# Altium schematic sheet main.SchDoc(main)
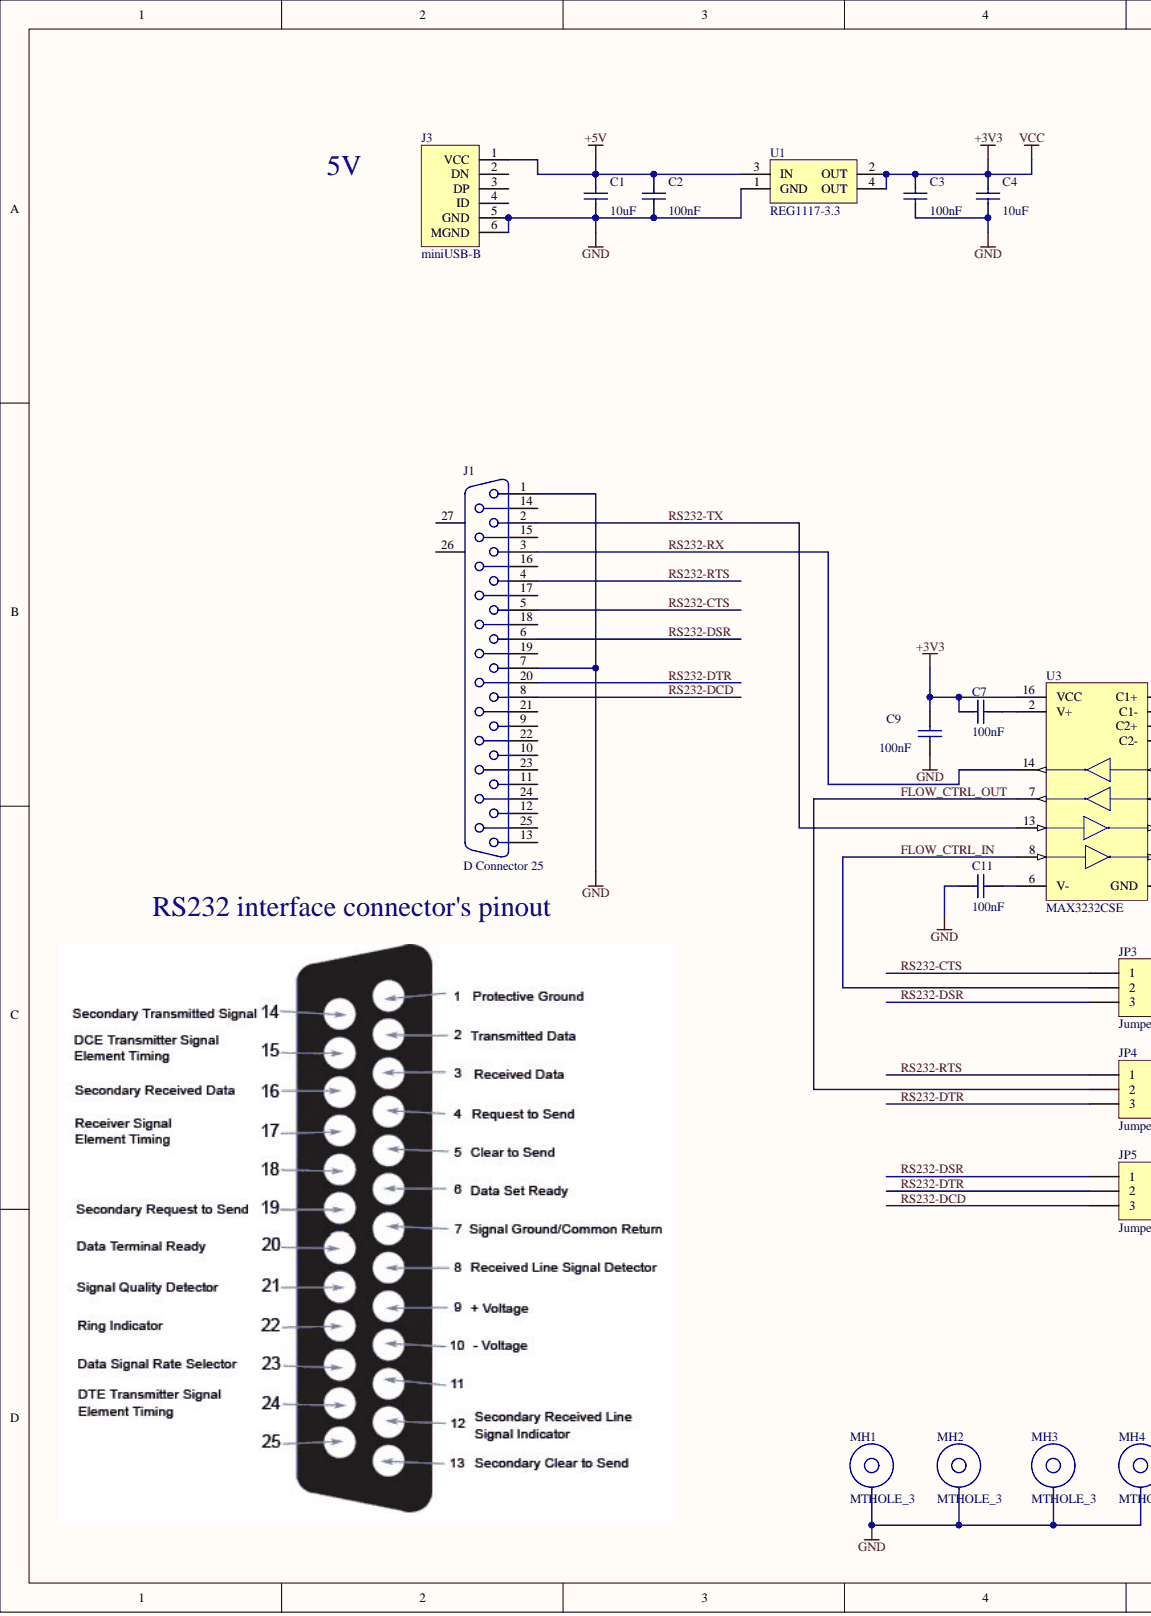
[diagram p0: main.SchDoc, left]
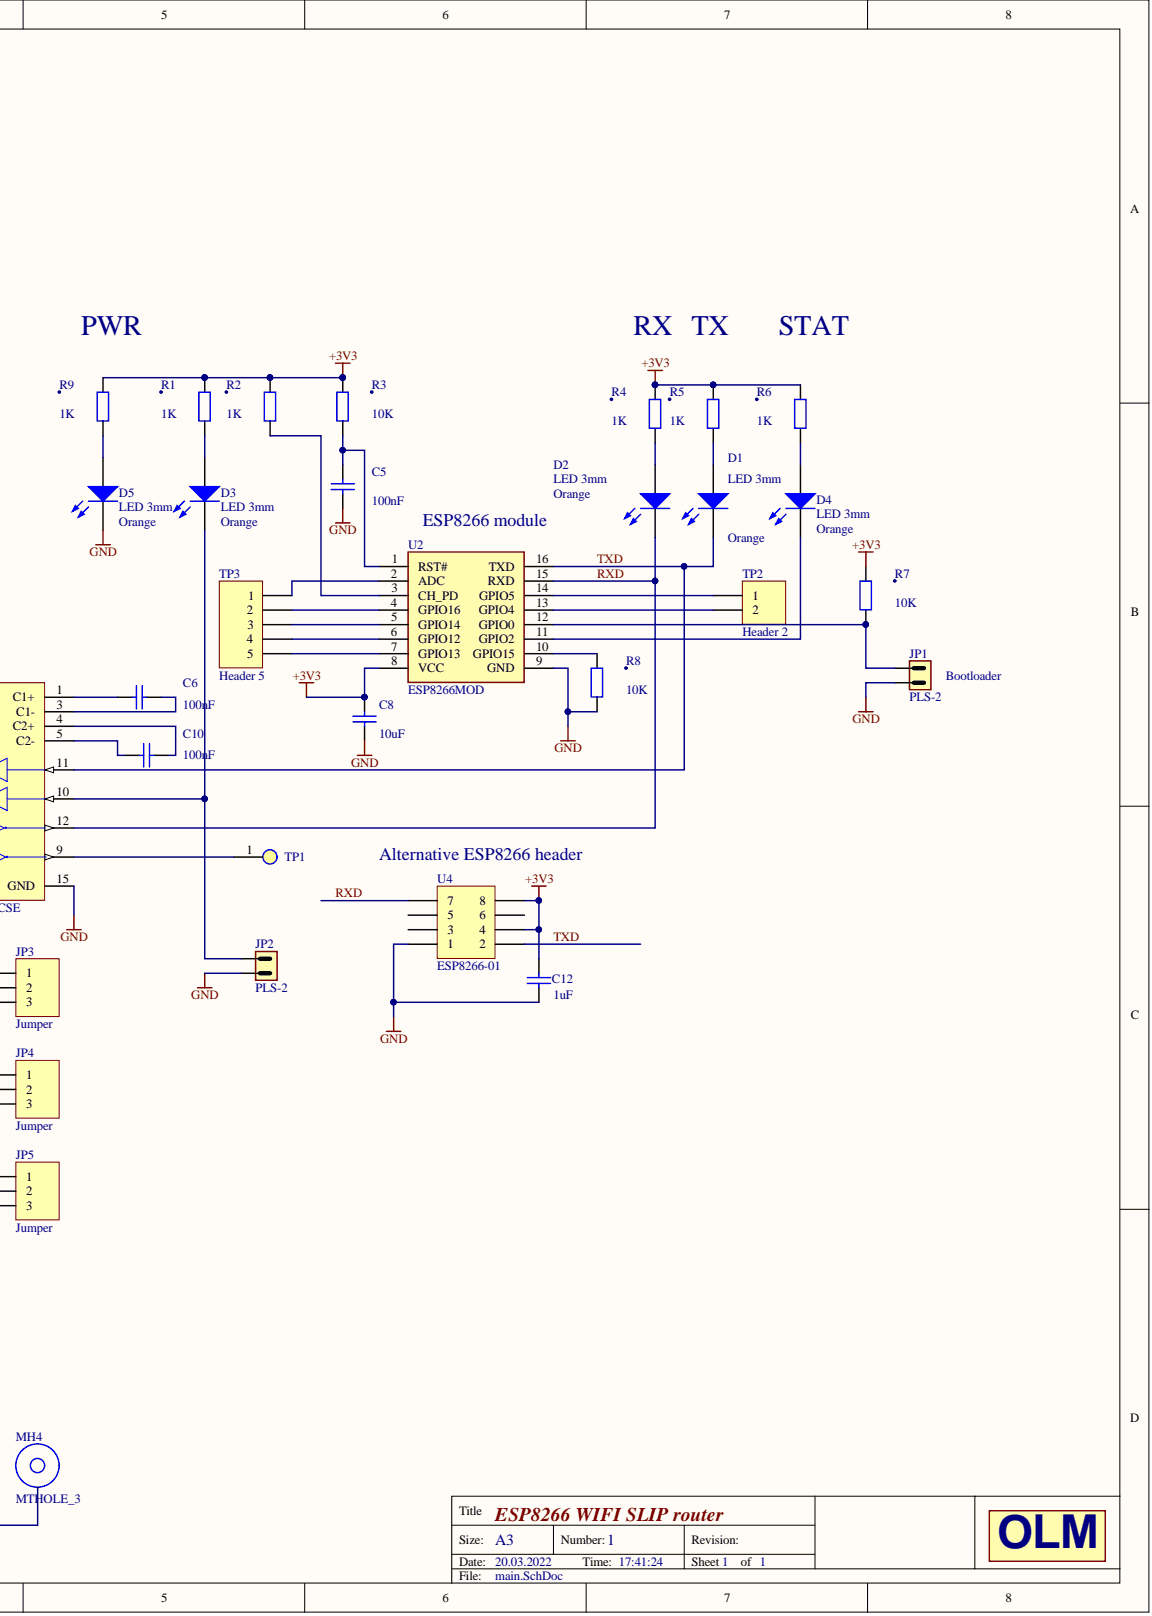
[diagram p1: main.SchDoc, right]

## Parts
C1  value 10uF  [SM/C_1206]  (hidden comment: Capacitor)
C2  value 100nF  [SM/C_0805]  (hidden comment: Capacitor)
C3  value 100nF  [SM/C_0805]  (hidden comment: Capacitor)
C4  value 10uF  [SM/C_1206]  (hidden comment: Capacitor)
C5  value 100nF  [SM/C_0805]  (hidden comment: Capacitor)
C6  value 100nF  [SM/C_0805]  (hidden comment: Capacitor)
C7  value 100nF  [SM/C_0805]  (hidden comment: Capacitor)
C8  value 10uF  [SM/C_1206]  (hidden comment: Capacitor)
C9  value 100nF  [SM/C_0805]  (hidden comment: Capacitor)
C10  value 100nF  [SM/C_0805]  (hidden comment: Capacitor)
C11  value 100nF  [SM/C_0805]  (hidden comment: Capacitor)
C12  1uF  [SM/C_1206]
D1  LED 3mm  value Orange  [LED_d3_green_sp8.64]
D2  LED 3mm  value Orange  [LED_d3_green_sp8.64]
D3  LED 3mm  value Orange  Part: LED 3mm Orange  [LED_d3_green_sp8.64]
D4  LED 3mm  value Orange  Part: LED 3mm Orange  [LED_d3_green_sp8.64]
D5  LED 3mm  value Orange  [LED_d3_green_sp8.64]
J1  D Connector 25  [SM/DB-25_EDGE]
J3  miniUSB-B  [miniUSB-B]
JP1  value PLS-2  [pls-2]  (hidden comment: Разъем)
JP2  value PLS-2  [pls-2]  (hidden comment: Разъем)
JP3  Jumper  [HDR1X3]
JP4  Jumper  [HDR1X3]
JP5  Jumper  [HDR1X3]
MH1  MTHOLE_3  [MTHOLE_3.5MM/5MM]  (no BOM)
MH2  MTHOLE_3  [MTHOLE_3.5MM/5MM]  (no BOM)
MH3  MTHOLE_3  [MTHOLE_3.5MM/5MM]  (no BOM)
MH4  MTHOLE_3  [MTHOLE_3.5MM/5MM]  (no BOM)
R1  value 1K  [SM/R_0805]  (hidden comment: Resistor)
R2  value 1K  [SM/R_0805]  (hidden comment: Resistor)
R3  value 10K  [SM/R_0805]  (hidden comment: Resistor)
R4  value 1K  [SM/R_0805]  (hidden comment: Resistor)
R5  value 1K  [SM/R_0805]  (hidden comment: Resistor)
R6  value 1K  [SM/R_0805]  (hidden comment: Resistor)
R7  value 10K  [SM/R_0805]  (hidden comment: Resistor)
R8  value 10K  [SM/R_0805]  (hidden comment: Resistor)
R9  value 1K  [SM/R_0805]  (hidden comment: Resistor)
TP1  Перемычка  [MTHOLE_1.4/2]  (value not drawn)
TP2  Header 2  [HDR1X2]
TP3  Header 5  [HDR1X5]
U1  value REG1117-3.3  [SM/SOT223_1234]  (hidden comment: LDO)
U2  value ESP8266MOD  [ESP8266MOD]  (hidden comment: WIFI module)
U3  MAX3232CSE  [NSO16_N]
U4  ESP8266-01  [PBD-8]

## Nets
+3V3: C3.2 C4.2 C7.2 C8.2 C9.1 C12.2 R1.2 R2.2 R3.2 R4.2 R5.2 R6.2 R7.2 R9.2 U1.2(OUT) U1.4(OUT) U2.8(VCC) U3.16(VCC) U4.4 U4.8
+5V: C1.2 C2.2 J3.1(VCC) U1.3(IN)
GND: C1.1 C2.1 C3.1 C4.1 C5.1 C8.1 C9.2 C11.2 C12.1 D5.2 J1.1 J1.7 J3.5(GND) J3.6(MGND) JP1.2 JP2.2 MH1.1 MH2.1 MH3.1 MH4.1 R8.1 U1.1(GND) U2.9(GND) U3.15(GND) U4.1
FLOW_CTRL_IN: JP3.2 U3.8
FLOW_CTRL_OUT: JP4.2 U3.7
RS232-CTS: J1.5 JP3.1
RS232-DCD: J1.8 JP5.3
RS232-DSR: J1.6 JP3.3 JP5.1
RS232-DTR: J1.20 JP4.3 JP5.2
RS232-RTS: J1.4 JP4.1
RS232-RX: J1.3 U3.14
RS232-TX: J1.2 U3.13
RXD: D2.2 U2.15(RXD) U3.12 U4.7
TXD: D1.2 U2.16(TXD) U3.11 U4.2
NetC5_2: C5.2 R3.1 U2.1(RST#)
NetC6_1: C6.1 U3.3(C1-)
NetC6_2: C6.2 U3.1(C1+)
NetC7_1: C7.1 U3.2(V+)
NetC10_1: C10.1 U3.4(C2+)
NetC10_2: C10.2 U3.5(C2-)
NetC11_1: C11.1 U3.6(V-)
NetD1_1: D1.1 R5.1
NetD2_1: D2.1 R4.1
NetD3_1: D3.1 R1.1
NetD3_2: D3.2 JP2.1 U3.10
NetD4_1: D4.1 R6.1
NetD4_2: D4.2 U2.11(GPIO2)
NetD5_1: D5.1 R9.1
NetJP1_1: JP1.1 R7.1 U2.12(GPIO0)
NetR2_1: R2.1 U2.3(CH_PD)
NetR8_2: R8.2 U2.10(GPIO15)
NetTP1_1: TP1.1 U3.9
NetTP2_1: TP2.1 U2.14(GPIO5)
NetTP2_2: TP2.2 U2.13(GPIO4)
NetTP3_1: TP3.1 U2.2(ADC)
NetTP3_2: TP3.2 U2.4(GPIO16)
NetTP3_3: TP3.3 U2.5(GPIO14)
NetTP3_4: TP3.4 U2.6(GPIO12)
NetTP3_5: TP3.5 U2.7(GPIO13)

Not connected: J1 9 10 11 12 13 14 15 16 17 18 19 21 22 23 24 25 26 27; J3 2(DN) 3(DP) 4(ID); U4 3 5 6

## Library data of the parts
C1 C4 C8 = Cap ceramic: Ceramic capacitor SMD; Manufacturer: Kemet; Part: C1206C106K4RACTU; Component Kind: Standard; Library Name: New.SCHLIB
C2 C3 C5-C7 C9-C11 = Cap ceramic: Ceramic capacitor SMD; Manufacturer: Samsung; Part: CL10B104KB8NNNL; Component Kind: Standard; Library Name: New.SCHLIB
C12 = Cap: Capacitor; Component Kind: Standard; LatestRevisionDate: 17-Jul-2002; LatestRevisionNote: Re-released for DXP Platform.; Library Name: Miscellaneous Devices.IntLib; PackageDescription: Radial Cap, Thru-Hole; 2 Leads; 0.3 in Pin Spacing; PackageReference: RAD-0.3; Signal Integrity: Cap; Simulation: CAP; Value: 1uF; Publisher: Altium Limited
D1 D2 D5 = LED0: LED d3mm; Part: LED 3mm Orange; Примечание: Kingbright; Component Kind: Standard; LatestRevisionDate: 08-Jul-2005; LatestRevisionNote: Mech Layer 1 removed.; Library Name: Miscellaneous Devices.IntLib; PackageDescription: LED; 2 Leads; PackageReference: LED-0; Published: 8-Jun-2000; Simulation: LED0; Publisher: Altium Limited
D3 D4 = LED0: LED d3mm; Примечание: Kingbright; Component Kind: Standard; LatestRevisionDate: 08-Jul-2005; LatestRevisionNote: Mech Layer 1 removed.; Library Name: Miscellaneous Devices.IntLib; PackageDescription: LED; 2 Leads; PackageReference: LED-0; Published: 8-Jun-2000; Simulation: LED0; Publisher: Altium Limited
J1 = D Connector 25: Receptacle Assembly, 25 Position, Right Angle; PackageDocument: U; Positions: 25; Component Kind: Standard; DatasheetDocument: 19-Mar-1999; Gender: Receptacle; LatestRevisionDate: 17-Jul-2002; LatestRevisionNote: Re-released for DXP Platform.; Library Name: Miscellaneous Connectors.IntLib; Orientation: Right Angle; PackageReference: 787202; Published: 15-Jun-2001; Publisher: Altium Limited
J3 = miniUSB-B: miniUSB type B SMD connector; Component Kind: Standard; Library Name: Connectors.SchLib
JP1 JP2 = Jumper2: Jumper Wire; Manufacturer: Generic Components; Component Kind: Standard; Library Name: New_home.SCHLIB; Published: 1999
JP3-JP5 = Header 3: Header, 3-Pin; Component Kind: Standard; LatestRevisionDate: 17-Jul-2002; LatestRevisionNote: Re-released for DXP Platform.; Library Name: Miscellaneous Connectors.IntLib; Signal Integrity: Connector; Publisher: Altium Limited
R1-R4 R6-R9 = Res2: Part: 0R 5% 0603; Component Kind: Standard; Description: Resistor; LatestRevisionDate: 17-Jul-2002; LatestRevisionNote: Re-released for DXP Platform.; Library Name: Miscellaneous Devices.IntLib; PackageReference: AXIAL-0.4; Published: 8-Jun-2000; Signal Integrity: Res; Simulation: RESISTOR; Publisher: Altium Limited
R5 = Res2: Part: 0R 5% 0603; Value: 1K; Component Kind: Standard; Description: Resistor; LatestRevisionDate: 17-Jul-2002; LatestRevisionNote: Re-released for DXP Platform.; Library Name: Miscellaneous Devices.IntLib; PackageReference: AXIAL-0.4; Published: 8-Jun-2000; Signal Integrity: Res; Simulation: RESISTOR; Publisher: Altium Limited
TP1 = Jumper-1: small tac switch; Component Kind: Standard; Library Name: New_home.SCHLIB
TP2 = Header 2: Header, 2-Pin; Component Kind: Standard; LatestRevisionDate: 17-Jul-2002; LatestRevisionNote: Re-released for DXP Platform.; Library Name: Miscellaneous Connectors.IntLib; Signal Integrity: Connector; Publisher: Altium Limited
TP3 = Header 5: Header, 5-Pin; Component Kind: Standard; LatestRevisionDate: 17-Jul-2002; LatestRevisionNote: Re-released for DXP Platform.; Library Name: Miscellaneous Connectors.IntLib; Signal Integrity: Connector; Publisher: Altium Limited
U1 = REG1117-3.3: 800mA and 1A Low Dropout Positive Regulator 2.85V, 3.3V, 5V, and Adjustable; Component Kind: Standard; ComponentLink1Description: Manufacturer Link; ComponentLink1URL: http://www.ti.com/; ComponentLink2Description: Datasheet; ComponentLink2URL: http://www-s.ti.com/sc/ds/REG1117.pdf; DatasheetVersion: Sep-1997; LatestRevisionDate: 17-Jul-2002; LatestRevisionNote: Re-released for DXP Platform.; Library Name: BB Power Mgt Voltage Regulator.IntLib; PackageDescription: SOT-223/SC-73; 4 Leads; Body 6.7 x 7.3 mm, inc. leads (LxW max); PackageReference: ZZ311; PackageVersion: revC; Part: REG1117-3.3; Published: 8-Jun-2000; Publisher: Altium Limited
U2 = ESP8266MOD: Inverter; Component Kind: Standard; Library Name: New_home.SCHLIB
U3 = MAX3232CSE: 3.0V TO 5.5V, Low-Power, up to 1Mbps, True RS-232 Transceiver Using Four 0.1µF External Capacitor; Code_IPC: SOIC127P600-16; Code_JEDEC: MS-012-AC; Component Kind: Standard; ComponentLink1Description: Manufacturer Link; ComponentLink1URL: http://www.maxim-ic.com/; ComponentLink2Description: Datasheet; ComponentLink2URL: http://pdfserv.maxim-ic.com/en/ds/MAX3222-MAX3241.pdf; DatasheetVersion: Mar-1999; LatestRevisionDate: 13-Apr-2006; LatestRevisionNote: IPC-7351 Footprint Added.; Library Name: Maxim Communication Transceiver.IntLib; PackageDescription: 16-Pin Small Outline Integrated Circuit 1.27 mm Pitch; PackageReference: NSO16; PCB3D: NSO16; Published: 16-Jan-2001; Publisher: Altium Limited
U4 = Header 4X2: Header, 4-Pin, Dual row; Component Kind: Standard; LatestRevisionDate: 17-Jul-2002; LatestRevisionNote: Re-released for DXP Platform.; Library Name: Miscellaneous Connectors.IntLib; Signal Integrity: Connector; Publisher: Altium Limited
MH1-MH4 = MTHOLE_3: Library Name: New_home.SCHLIB
